# Altium schematic sheet STM32 FillLab WalkThrough.SchDoc
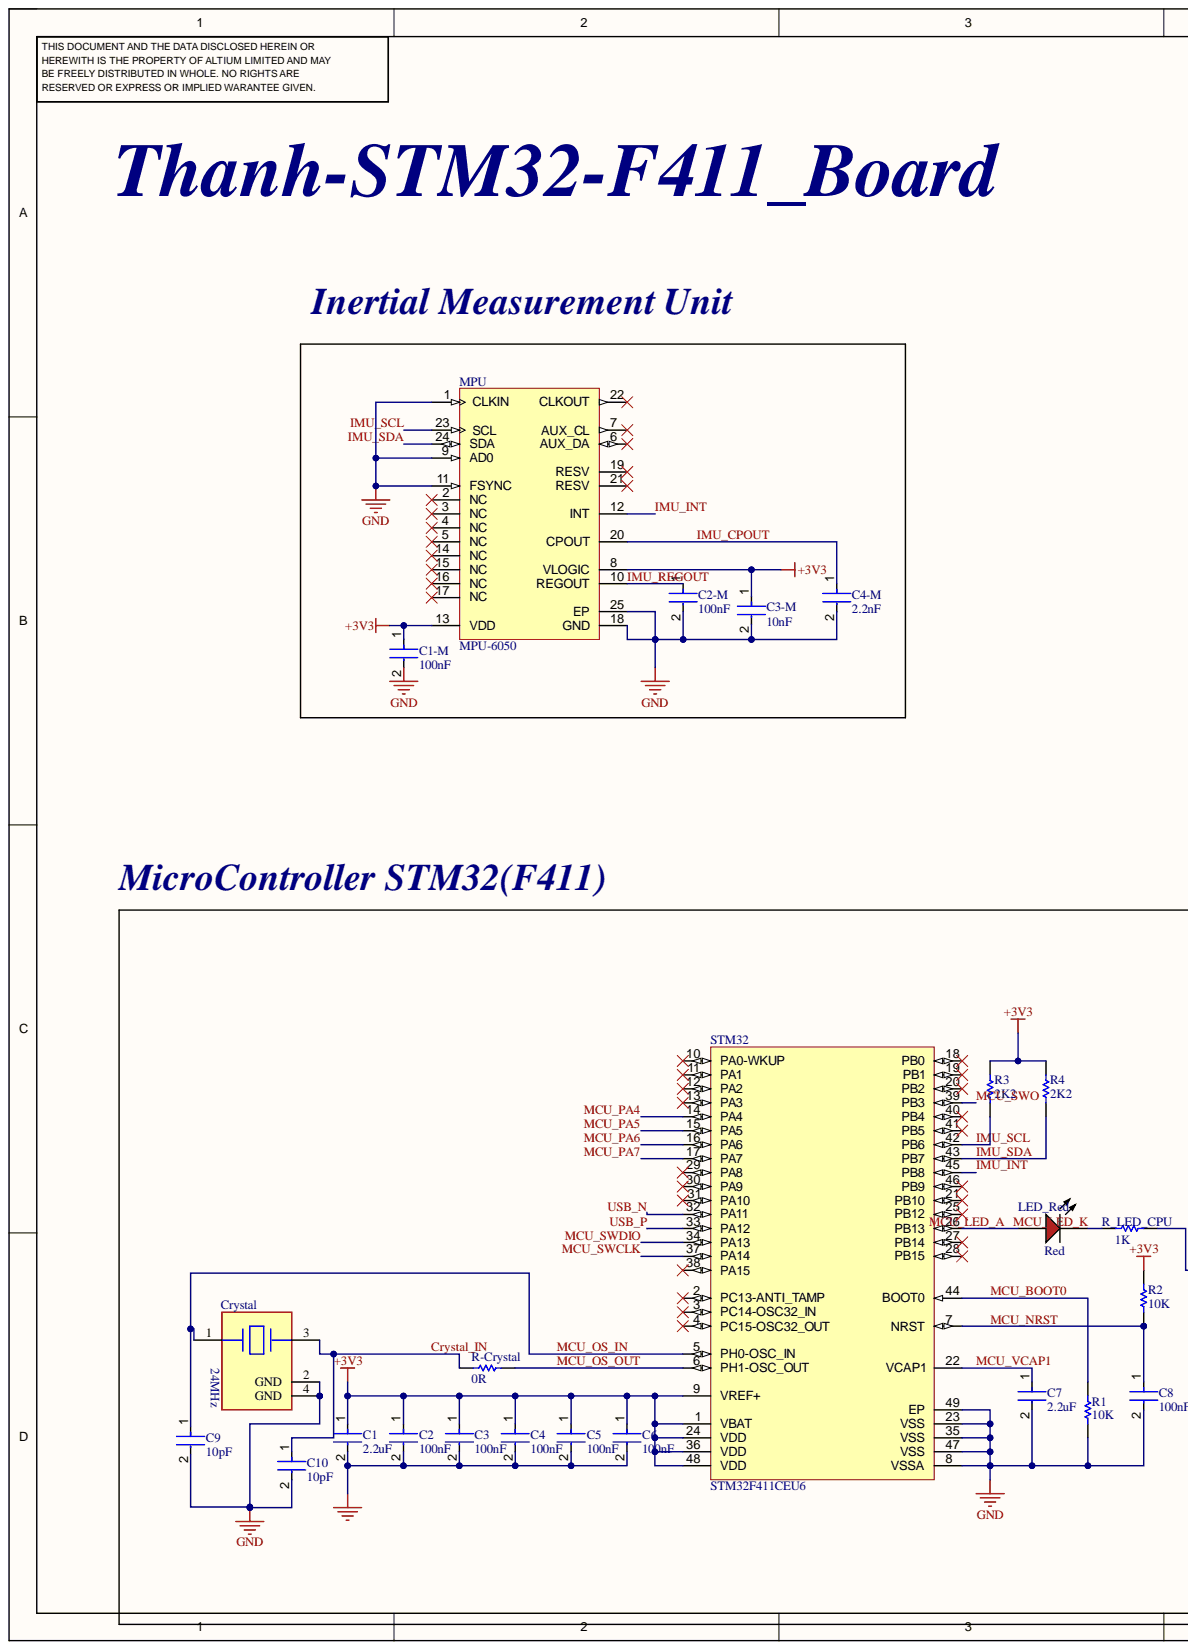
[diagram p0: STM32 FillLab WalkThrough.SchDoc, left]
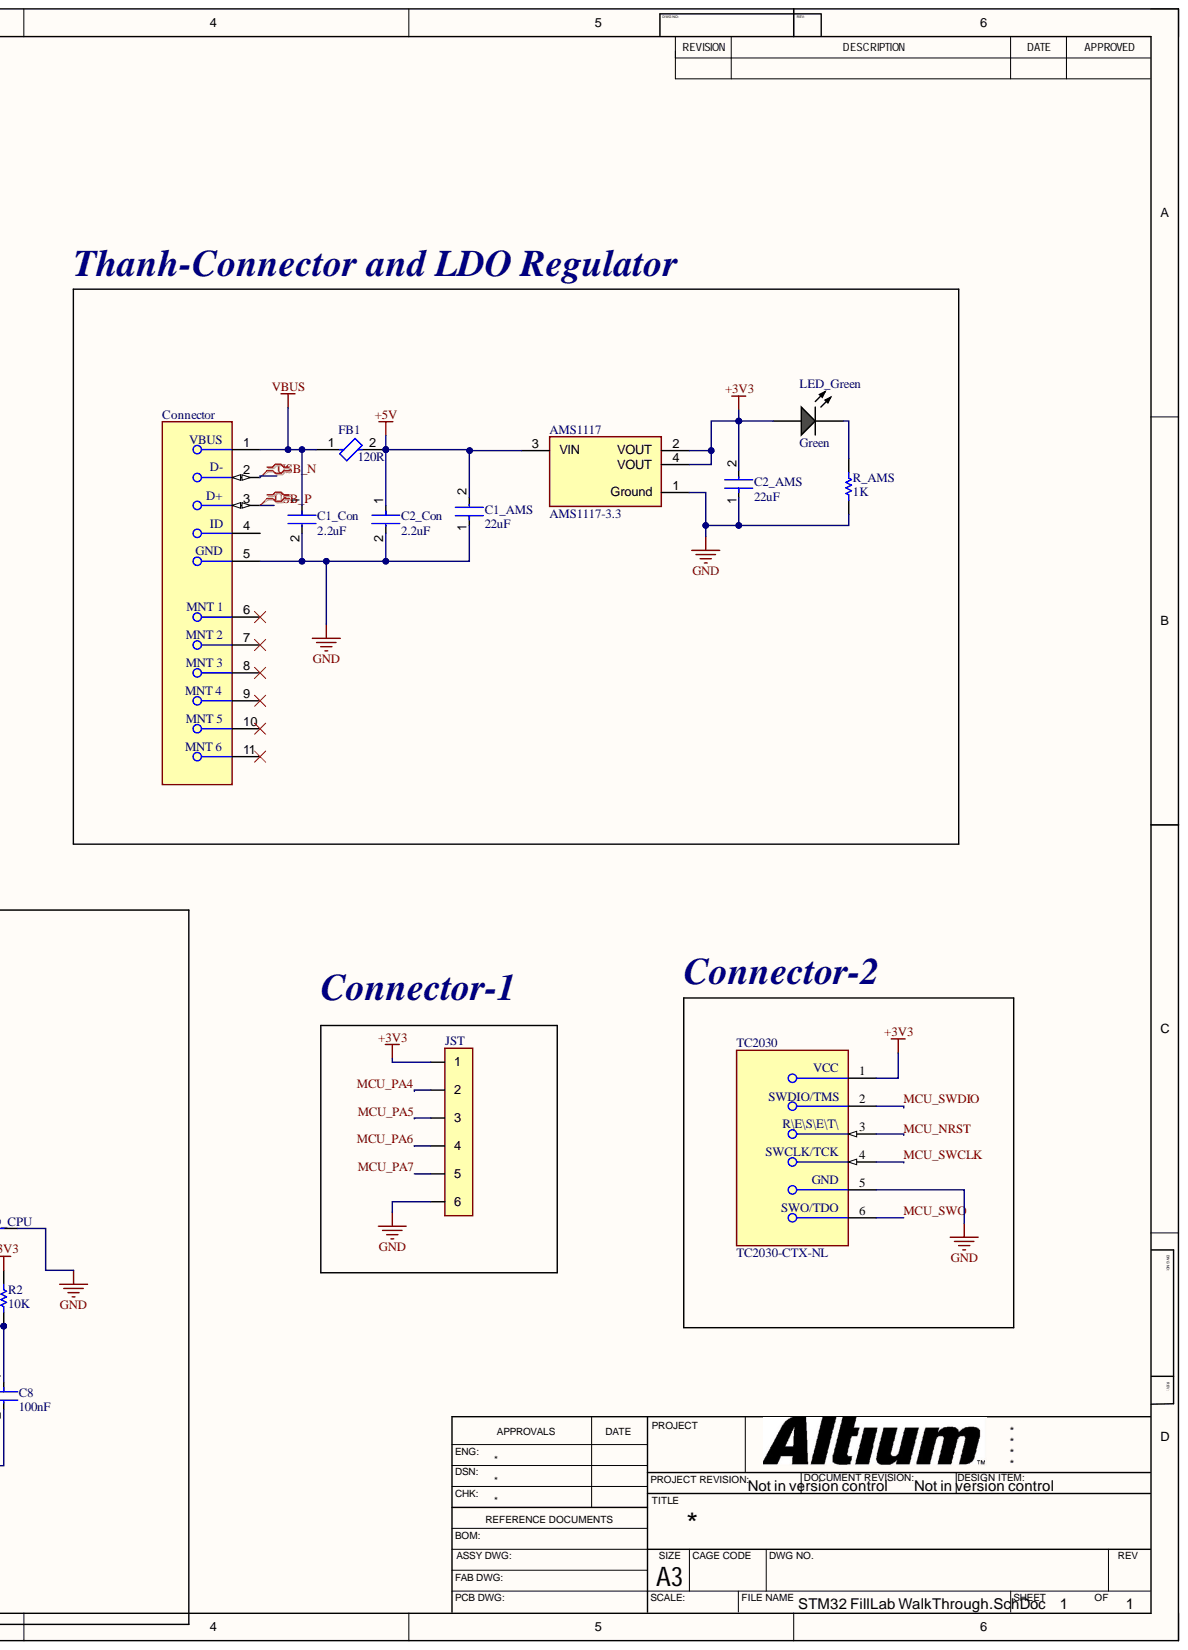
[diagram p1: STM32 FillLab WalkThrough.SchDoc, right]

## Parts
AMS1117  AMS1117-3.3  Package: AMS1117  [FP-AMS1117-IPC_C]
C1  2.2uF  [FP-C0402-BB-MFG]
C1-M  100nF  [FP-C0402-BB-MFG]
C1_AMS  22uF  [FP-C0402-BB-MFG]
C1_Con  2.2uF  [FP-C0402-BB-MFG]
C2  100nF  [FP-C0402-BB-MFG]
C2-M  100nF  [FP-C0402-BB-MFG]
C2_AMS  22uF  [FP-C0402-BB-MFG]
C2_Con  2.2uF  [FP-C0402-BB-MFG]
C3  100nF  [FP-C0402-BB-MFG]
C3-M  10nF  [FP-C0402-BB-MFG]
C4  100nF  [FP-C0402-BB-MFG]
C4-M  2.2nF  [FP-C0402-BB-MFG]
C5  100nF  [FP-C0402-BB-MFG]
C6  100nF  [FP-C0402-BB-MFG]
C7  2.2uF  [FP-C0402-BB-MFG]
C8  100nF  [FP-C0402-BB-MFG]
C9  10pF  [FP-C0402-BB-MFG]
C10  10pF  [FP-C0402-BB-MFG]
Connector  473460001  [FP-473460001-MFG]  (value not drawn)
Crystal  24MHz  [FP-ABM8-MFG]
FB1  120R  [FP-BLM15-0_05-IPC_B]
JST  SM06B-GHS-TB  [JST-GH-6]  (value not drawn)
LED_Green  Green  [AVAG-HSMX-C170_V]
LED_Red  Red  [AVAG-HSMX-C150_V]
MPU  MPU-6050  [IS-QFN-24_V]
R1  10K  [RESC1609X50X30NL8T20]
R2  10K  [RESC1609X50X30NL8T20]
R3  2K2  [RESC1609X50X30NL8T20]
R4  2K2  [RESC1609X50X30NL8T20]
R-Crystal  0R  [RESC1609X50X30NL8T20]
R_AMS  1K  [RESC1609X50X30NL8T20]
R_LED_CPU  1K  [RESC1609X50X30NL8T20]
STM32  STM32F411CEU6  [STM-UFQFPN48_L]
TC2030  TC2030-CTX-NL  [FP-TC2030-CTX-NL-MFG]

## Nets
+3V3: AMS1117.2(VOUT) AMS1117.4(VOUT) C1.1 C1-M.1 C2.1 C2_AMS.2 C3.1 C3-M.1 C4.1 C5.1 C6.1 JST.P$1 LED_Green.1 MPU.8(VLOGIC) MPU.13(VDD) R2.2 R3.2 R4.2 STM32.1(VBAT) STM32.9(VREF+) STM32.24(VDD) STM32.36(VDD) STM32.48(VDD) TC2030.1
+5V: AMS1117.3(VIN) C1_AMS.2 C2_Con.1 FB1.2
GND: AMS1117.1(Ground) C1.2 C1-M.2 C1_AMS.1 C1_Con.2 C2.2 C2-M.2 C2_AMS.1 C2_Con.2 C3.2 C3-M.2 C4.2 C4-M.2 C5.2 C6.2 C7.2 C8.2 C9.2 C10.2 Connector.5 Crystal.2(GND) Crystal.4(GND) JST.P$6 MPU.1(CLKIN) MPU.9(AD0) MPU.11(FSYNC) MPU.18(GND) MPU.25(EP) R1.1 R_AMS.1 R_LED_CPU.1 STM32.8(VSSA) STM32.23(VSS) STM32.35(VSS) STM32.47(VSS) STM32.49(EP) TC2030.5
VBUS: C1_Con.1 Connector.1 FB1.1
Crystal_IN: C10.1 Crystal.3 R-Crystal.2
IMU_CPOUT: C4-M.1 MPU.20(CPOUT)
IMU_INT: MPU.12(INT) STM32.45(PB8)
IMU_REGOUT: C2-M.1 MPU.10(REGOUT)
IMU_SCL: MPU.23(SCL) R3.1 STM32.42(PB6)
IMU_SDA: MPU.24(SDA) R4.1 STM32.43(PB7)
MCU_BOOT0: R1.2 STM32.44(BOOT0)
MCU_LED_A: LED_Red.1 STM32.26(PB13)
MCU_LED_K: LED_Red.2 R_LED_CPU.2
MCU_NRST: C8.1 R2.1 STM32.7(NRST) TC2030.3
MCU_OS_IN: C9.1 Crystal.1 STM32.5(PH0-OSC_IN)
MCU_OS_OUT: R-Crystal.1 STM32.6(PH1-OSC_OUT)
MCU_PA4: JST.P$2 STM32.14(PA4)
MCU_PA5: JST.P$3 STM32.15(PA5)
MCU_PA6: JST.P$4 STM32.16(PA6)
MCU_PA7: JST.P$5 STM32.17(PA7)
MCU_SWCLK: STM32.37(PA14) TC2030.4
MCU_SWDIO: STM32.34(PA13) TC2030.2
MCU_SWO: STM32.39(PB3) TC2030.6
MCU_VCAP1: C7.1 STM32.22(VCAP1)
USB_N: Connector.2 STM32.32(PA11)
USB_P: Connector.3 STM32.33(PA12)
NetLED_Green_2: LED_Green.2 R_AMS.2

Not connected: Connector 4 6 7 8 9 10 11; MPU 2(NC) 3(NC) 4(NC) 5(NC) 6(AUX_DA) 7(AUX_CL) 14(NC) 15(NC) 16(NC) 17(NC) 19(RESV) 21(RESV) 22(CLKOUT); STM32 2(PC13-ANTI_TAMP) 3(PC14-OSC32_IN) 4(PC15-OSC32_OUT) 10(PA0-WKUP) 11(PA1) 12(PA2) 13(PA3) 18(PB0) 19(PB1) 20(PB2) 21(PB10) 25(PB12) 27(PB14) 28(PB15) 29(PA8) 30(PA9) 31(PA10) 38(PA15) 40(PB4) 41(PB5) 46(PB9)

## Library data of the parts
AMS1117 = CMP-209535-000001-1: LDO Voltage Regulators 1A, 3.3V; Manufacturer: Advanced Monolithic Systems; Dropout Voltage: 1.1V; Max Junction Temp: +125°C; Max Power Dissipation: 1.2W; Max Supply Voltage: 15V; Nominal Supply Current: 5mA; Number Of Outputs: 1; Output Type: Fixed; Standoff Height: 0.64mm; Automotive: No; Category: IC; Component Kind: Standard; ComponentLink2Description: Datasheet; ComponentLink2URL: http://www.advanced-monolithic.com/pdf/ds1117.pdf; Device Class L1: Integrated Circuits (ICs); Device Class L2: Power Management ICs; Device Class L3: Voltage Regulators - Linear; Height: 1.8mm; Ipc Land Pattern Name: SOT229P700X180-4; Lead Free: Yes; Library Name: Altium Content Vault; Manufacturer Part Number: AMS1117-3.3; Octopart: https://octopart.com/ams1117-3.3-advanced+monolithic+systems-25899300; Output Current: 1A; Output Voltage: 3.3V; RoHS: TRUE; Temperature: -40°C to +125°C; Temperature Range High: +125°C; Temperature Range Low: -40°C
C1 C1-M C1_AMS C1_Con C2 C2-M C2_AMS C2_Con C3 C3-M C4 C4-M C5-C10 = CMP-2008-02046-2: CAP CER 0.1UF 10V X5R 0402; Manufacturer: Kemet; Manufacturer Part Number: C0402C104K8PACTU; Capacitance: 0.10 μF; Material: Ceramic; Temperature Coefficient: 15%; Tolerance: 0.1; Value: 0.10 μF; Automotive: No; Category: Cap; Component Kind: Standard; ComponentLink2Description: Datasheet; ComponentLink2URL: https://content.kemet.com/datasheets/KEM_C1002_X7R_SMD.pdf; Device Class L1: Passive Components; Device Class L2: Capacitors; Device Class L3: Ceramic Capacitors; Digikey Description: CAP CER 0.1UF 10V X5R 0402; Height: 0.55mm; Ipc Land Pattern Name: CAPC10050X50; Lead Free: Yes; Library Name: Altium Content Vault; Mouser Description: Capacitor 100 nF 10 VDC 0402; Octopart: https://octopart.com/c0402c104k8pactu-kemet-140039; Package: C0402-BB; RoHS: TRUE; Temperature: -55°C to +125°C; Temperature Characteristic: X5R; Temperature Range High: +125°C; Temperature Range Low: -55°C; Voltage Rating: 10 V
Connector = CMP-2000-05246-2: CONN RCPT USB2.0 MICRO B SMD R/A; Manufacturer: Molex; Conn Orientation: Right Angle; Current Rating: 1.8A; Is Female: yes; Number Of Contacts: 5; Pitch: 0.65mm; Shielding: true; Voltage Rating: 30V; Automotive: No; Category: Conn; Component Kind: Standard; ComponentLink2Description: Datasheet; ComponentLink2URL: https://www.molex.com/webdocs/datasheets/pdf/en-us/0473460001_IO_CONNECTORS.pdf; Contact Material: Gold,Nickel; Device Class L1: Connectors; Device Class L2: USB Connectors; Device Class L3: unset; Digikey Description: CONN RCPT USB2.0 MICRO B SMD R/A; Footprint Url: https://www.molex.com/pdm_docs/sd/473460001_sd.pdf; Height: 2.945mm; Lead Free: Yes; Library Name: Altium Content Vault; Manufacturer Part Number: 473460001; Octopart: https://octopart.com/47346-0001-molex-7164928; Package: 0; RoHS: TRUE; Temperature: -30°C to +85°C; Temperature Range High: +85°C; Temperature Range Low: -30°C; Usb Standard: USB 2.0
Crystal = CMP-27762-000036-1: Crystal 24MHz ±20ppm 18pF SMD-4 3.2mm x 2.5mm; ESR (Equivalent Series Resistance): 50R; Frequency Stability: 50ppm; Accuracy: 20ppm; Case/Package: CSMD; Component Kind: Standard; Depth: 2.5mm; Frequency: 24MHz; Frequency Tolerance: 20ppm; Height: 0.031inch; Lead Free: Lead Free; Length: 3.2mm; Library Name: Altium Content Vault; Load Capacitance: 18pF; Max Operating Temperature: 70°C; Min Operating Temperature: -20°C; Mount: Surface Mount; Number of Pins: 4; Operating Frequency: 24 MHz; Package Quantity: 1000; Packaging: Tape and Reel; Radiation Hardening: No; REACH SVHC: No SVHC; Resistor Type: Ceramic; RoHS Compliant: Yes; Series: ABM8; Series Resistance: 50R; Termination: SMD/SMT; Tolerance: 20ppm; Width: 2.5mm
FB1 = CMP-0686-00181-5: Chip Ferrite Bead, 0402, 1000Ω @ 100MHz, 0.65Ω, 25%, 300mA; DC Current: 200mA; DC Resistance (DCR): 650mR; DC Resistance-Max: 1R; Impedance: 1kR; Number of Circuits: 1; Shielding: Unshielded; Case Code (Imperial): 0402; Case Code (Metric): 1005; Case/Package: 0402; Component Kind: Standard; Core Material: Ferrite; Current Rating: 300mA; Depth: 0.55mm; Frequency: 100MHz; Height: 0.5mm; Lead Free: Lead Free; Length: 1mm; Library Name: Altium Content Vault; Material: Ferrite; Max Current Rating: 300mA; Max DC Current: 200mA; Max Operating Temperature: 125°C; Military Standard: Not; Min Operating Temperature: -55°C; Number of Lines: 1; Number of Pins: 2; Package Quantity: 1; Packaging: Tape and Reel; Radiation Hardening: No; REACH SVHC: No SVHC; RoHS Compliant: Yes; Series: BLM15AG_SN; Termination: SMD/SMT; Test Frequency: 100MHz; Tolerance: 25%; Width: 0.5mm
JST = SM06B-GHS-TB: Connector Header Surface Mount, Right Angle 6 position 0.049 (1.25mm); MF: JST Sales; Check_prices: https://www.snapeda.com/parts/SM06B-GHS-TB/JST+Sales+America+Inc./view-part/?ref=eda; Component Kind: Standard; Library Name: SM06B-GHS-TB.IntLib; MP: SM06B-GHS-TB; Package: None; SnapEDA_Link: https://www.snapeda.com/parts/SM06B-GHS-TB/JST+Sales+America+Inc./view-part/?ref=snap
LED_Green = CMP-2000-05062-1: Chip LED, Green, 2.2 V, -40 to 85 degC, 2-Pin SMD, Pb-Free, Tape and Reel; Manufacturer: Avago; Bulb Size: 1.25mmx1.4mm; Dominant Wavelength: 572nm; External Depth: 0.8mm; External Length / Height: 2mm; External Width: 1.25mm; Forward Current If: 20mA; Forward Voltage: 2.2V; Forward Voltage VF Max: 2.6V; LED Type: Standard; Lens Shape: Rectangular; Light Area Height: 1mm; Luminous Intensity: 15mcd; Luminous Intensity Min: 4mcd; No. of Pins: 2; Opto Mounting Orientation: Top; Peak Wavelength: 570nm; PIV Max: 5V; SVHC: NoSVHC(16-Jun-2014); Viewing Angle: 170deg; Component Kind: Standard; Datasheet URL: https://datasheet.ciiva.com/29280/180495-da-01-en-chip-led-hsmg-c170-gelb-gruen-29280971.pdf; Datasheet Version: Oct-08; Dominant Wavelength Typ: 572nm; Forward Current If Max: 20mA; LED Colour: Green; LED Mounting: SMD; Library Name: Altium Content Vault; Luminous Intensity Typ: 15mcd; Manufacturer URL: http://www.avagotech.com/; Mounting Type: SMD; MSL: MSL2A-4weeks; Operating Temperature Max: 85degC; Operating Temperature Min: -30degC; Operating Temperature Range: -30degCto+85degC; Opto Case Style: SMD; Package Description: 2-Pin Surface Mount Device, Body 2 x 1.25 mm, Height 0.8 mm; Package Reference: HSMX-C170; Packaging: CutTape; Peak Forward Current: 20mA; Storage Temperature Max: 85degC; Storage Temperature Min: -40degC; Voltage Vf Typ: 2.2V; Wavelength Typ: 570nm
LED_Red = CMP-2000-06426-1: LED Uni-Color Red, 65 mW, 25 mA, -40 to 85 degC, 2-Pin SMD, RoHS, Tape and Reel; Manufacturer: Avago; Chip Material: GaAlAs; DELETED: Compliant; Dominant Wave Length: 639nm; Forward Current: 25mA; Forward Voltage: 2.6V; High Brightness: No; Lens Type: ColorlessDiffused; Lum Intensity: 17mcd; Main Category: ChipLED; Number of  Elements: 1; Peak Wavelength: 660nm; Power Dissipation: 78W; Product Depth (mm): 1.6mm; Product Height (mm): 1.1mm; Product Length (mm): 3.2mm; Reverse Voltage: 5V; Shape Type: Rectangular; Test Current (It): 20mA; Viewing Angle: 170deg; Component Kind: Standard; Datasheet URL: http://media.digikey.com/pdf/Data%20Sheets/Avago%20PDFs/HSMz-Czzz.pdf; Datasheet Version: 03/2012; Emitting Color: Red; Lens Dimensions: 2x1.6x0.6mm; Library Name: Altium Content Vault; Luminous Intensity: 17mcd; Manufacturer URL: http://www.avagotech.com/; Mounting: SurfaceMount; Mounting Technology: SurfaceMount; Operating Temp Range: -40Cto85C; Operating Temperature Classification: Industrial; Package Description: 2-Pin Surface Mount Device, Body 3.2 x 1.6 mm; Package Reference: HSMX-C150; Package Type: ChipLed; Packaging: TapeandReel; Pin Count: 2; Rad Hardened: No; Type: Uni-Color
MPU = CMP-1753-00002-2: 3-Axis Gyroscope, Accelerometer and a Digital Motion Processor(TM) (DMP), 2.375 to 3.46 V, -40 to 85 degC, 24-Pin QFN, RoHS, Tape and Reel; Manufacturer: InvenSense; Dynamic Catalog: MPU-6000™Series; Featured Product: MPU-60506-Axis,IntegratedI²CSolution; Other Names: 1428-1007-2  MPU6050; Sensor Type: MotionTracking; Category: Sensors,Transducers; Component Kind: Standard; Datasheet URL: http://datasheet.octopart.com/MPU-6050-InvenSense-datasheet-14421344.pdf; Datasheet Version: Rev. 3.3, 05/2012; Family: Multifunction; Library Name: Altium Content Vault; Manufacturer URL: http://www.invensense.com/; Online Catalog: MPU-6000™Series; Output Type: Digital; Standard Package: 5,000; Unit PriceUSD: Digital
R1-R4 R-Crystal R_AMS R_LED_CPU = CMP-1666-00005-4: Chip Resistor, 10 KOhm, +/- 1%, 100 mW, -55 to 155 degC, 0603 (1608 Metric), RoHS, Tape and Reel; Manufacturer: Vishay Semiconductor; Voltage Rating: 75 V; Component Kind: Standard; ComponentLink1Description: Manufacturer URL; ComponentLink1URL: http://www.vishay.com/; ComponentLink2Description: Datasheet; ComponentLink2URL: https://datasheet.ciiva.com/11809/0900766b80d98afc-11809037.pdf; DatasheetVersion: 06/2012; Height: 0.45 mm; Length: 1.55 mm; Library Name: Altium Content Vault; Max Operating Temperature: 155 degC; Min Operating Temperature: -55 degC; Mounting Technology: Surface Mount; Package Quantity: 5000; PackageDescription: 2-Pin Surface Mount Device, Body 1.575 x 0.85 mm; PackageReference: 0603; Packaging: Tape and Reel; REACH SVHC: Unknown; RoHSCompliant: true
STM32 = CMP-0243-00165-1: ARM Cortex-M4 32-bit MCU+FPU, 512 KB Flash, 128 KB RAM, 36 I/Os, 48-Pin UFQFPN, -40 to 85 degC, Tray; FLASH Size (Prog) (kB): 512; I/Os (High Current): 36; Internal RAM Size (kB): 128; Operating Frequency(F) (Processor speed) (MHz): 100; Supply Voltage(Vcc) min (V): 1.7; Component Kind: Standard; ComponentLink1Description: Manufacturer URL; ComponentLink1URL: http://www.st.com/; ComponentLink2Description: Datasheet; ComponentLink2URL: http://www.st.com/st-web-ui/static/active/en/resource/technical/document/datasheet/DM00115249.pdf; Core: ARM Cortex-M4; DatasheetVersion: Rev. 6; Library Name: Altium Content Vault; Mounting Technology: Surface Mount; Package Description: UFQFPN48; Package Reference: 48-Pin Ultra Thin Fine Pitch Quad Flat No-Lead, 7 x 7 x 0.6 mm Body, 0.5 mm Pitch, Exposed Pad; Packing: Tray
TC2030 = CMP-205963-000001-1: 6-Pin “No legs” TC2030 Plug-of-Nails™ Cable for use with ARM Cortex processors; Component Kind: Standard; Library Name: Altium Content Vault
